# Altium schematic sheet [4]-RF.SchDoc([4]-RF)
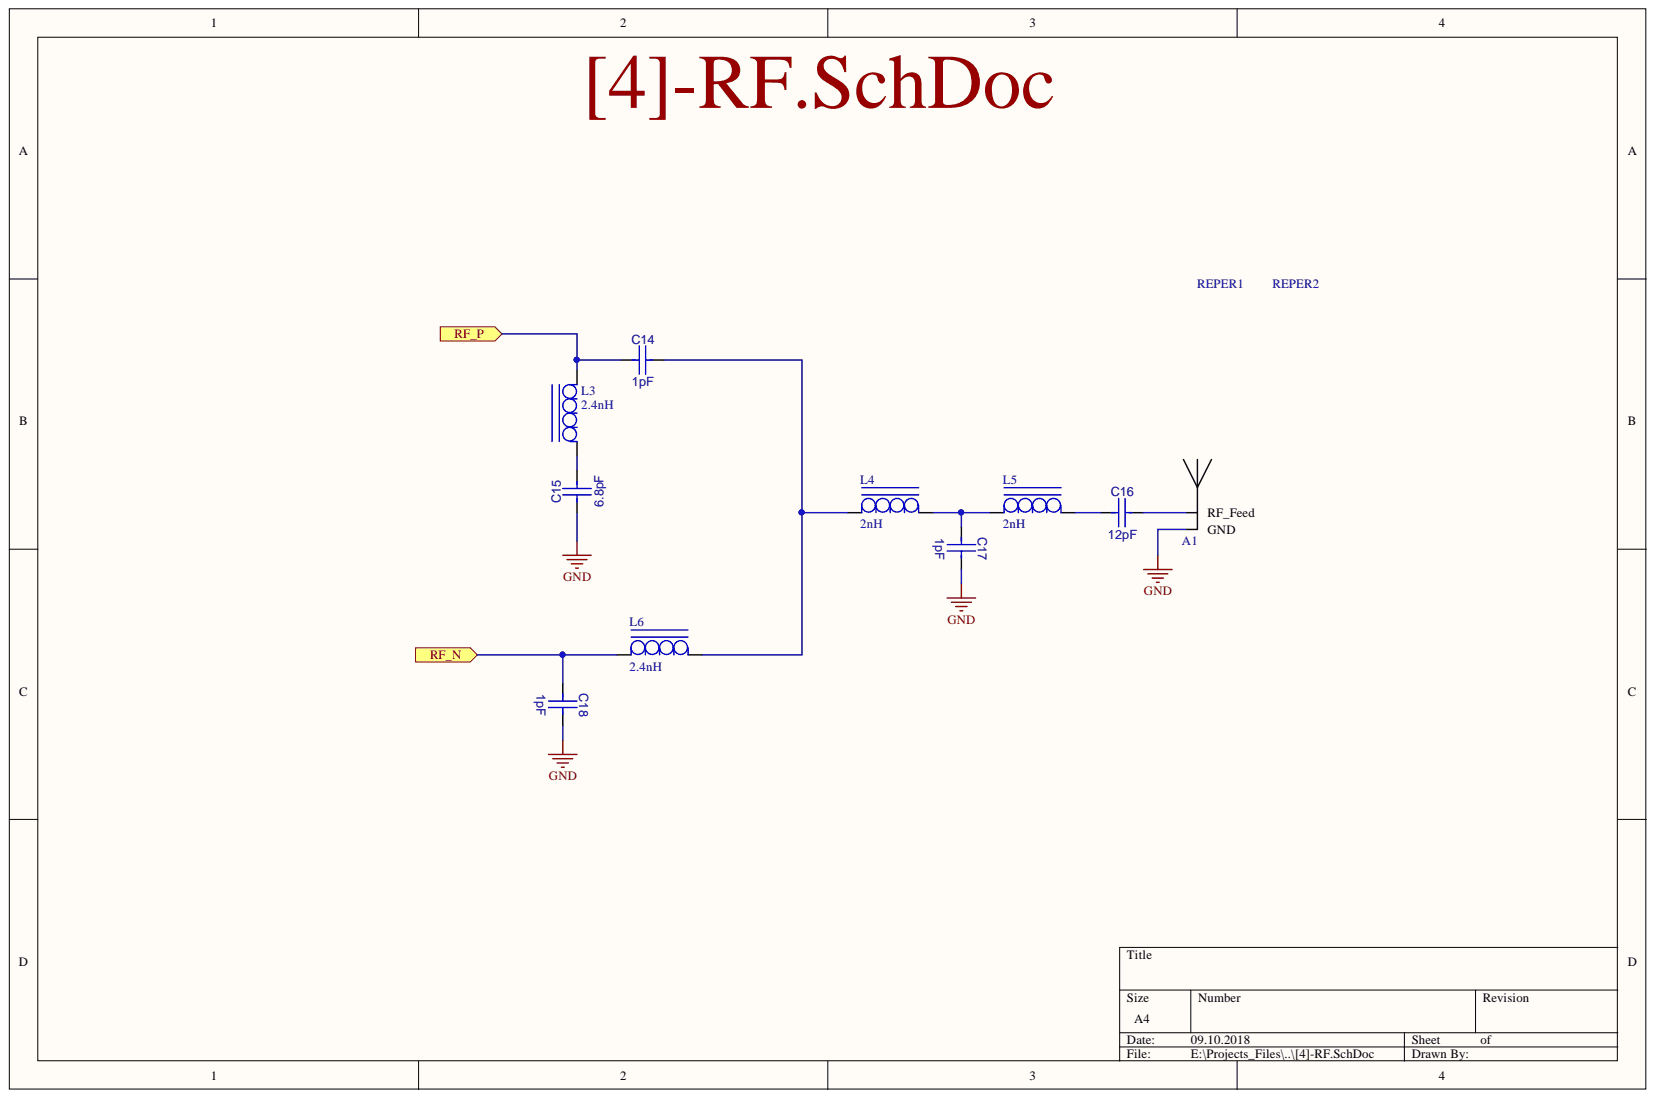
[diagram p0: [4]-RF.SchDoc]

## Parts
A1  Antenna Wi-Fi  [Antenna_WiFi]  (value not drawn)
C14  1pF  [CC0603]
C15  6.8pF  [CC0603]
C16  12pF  [CC0603]
C17  1pF  [CC0603]
C18  1pF  [CC0603]
L3  2.4nH  [L0402]
L4  2nH  [L0402]
L5  2nH  [L0402]
L6  2.4nH  [L0402]
REPER1  REPER1.2  [REPER1.2]  (value not drawn)
REPER2  REPER1.2  [REPER1.2]  (value not drawn)

## Ports
RF_N, RF_P

## Nets
GND: A1.2 C15.1 C17.2 C18.2
RF_N: C18.1 L6.1 ; port RF_N
RF_P: C14.1 L3.2 ; port RF_P
NetA1_1: A1.1 C16.2
NetC14_2: C14.2 L4.1 L6.2
NetC15_2: C15.2 L3.1
NetC16_1: C16.1 L5.2
NetC17_1: C17.1 L4.2 L5.1

## Library data of the parts
A1 = Antenna Wi-Fi: 2.43GHz; Component Kind: Standard; Library Name: fizik_lib.SchLib
C14-C18 = CC0603: Description: CC0603; Component Kind: Standard; Library Name: gprs_modem_2.SchLib
  C14 C17 C18: Value: 1pF
  C15: Value: 6.8pF
  C16: Value: 12pF
L3-L6 = L0402: Description: L0402; Component Kind: Standard; Library Name: gprs_modem_2.SchLib
  L3 L6: Value: 2.4nH
  L4 L5: Value: 2nH
REPER1 REPER2 = REPER1.2: Description: REPER1.2; Component Kind: Standard; Library Name: gprs_modem_2.SchLib
